# Altium schematic sheet Main.SchDoc(Main)
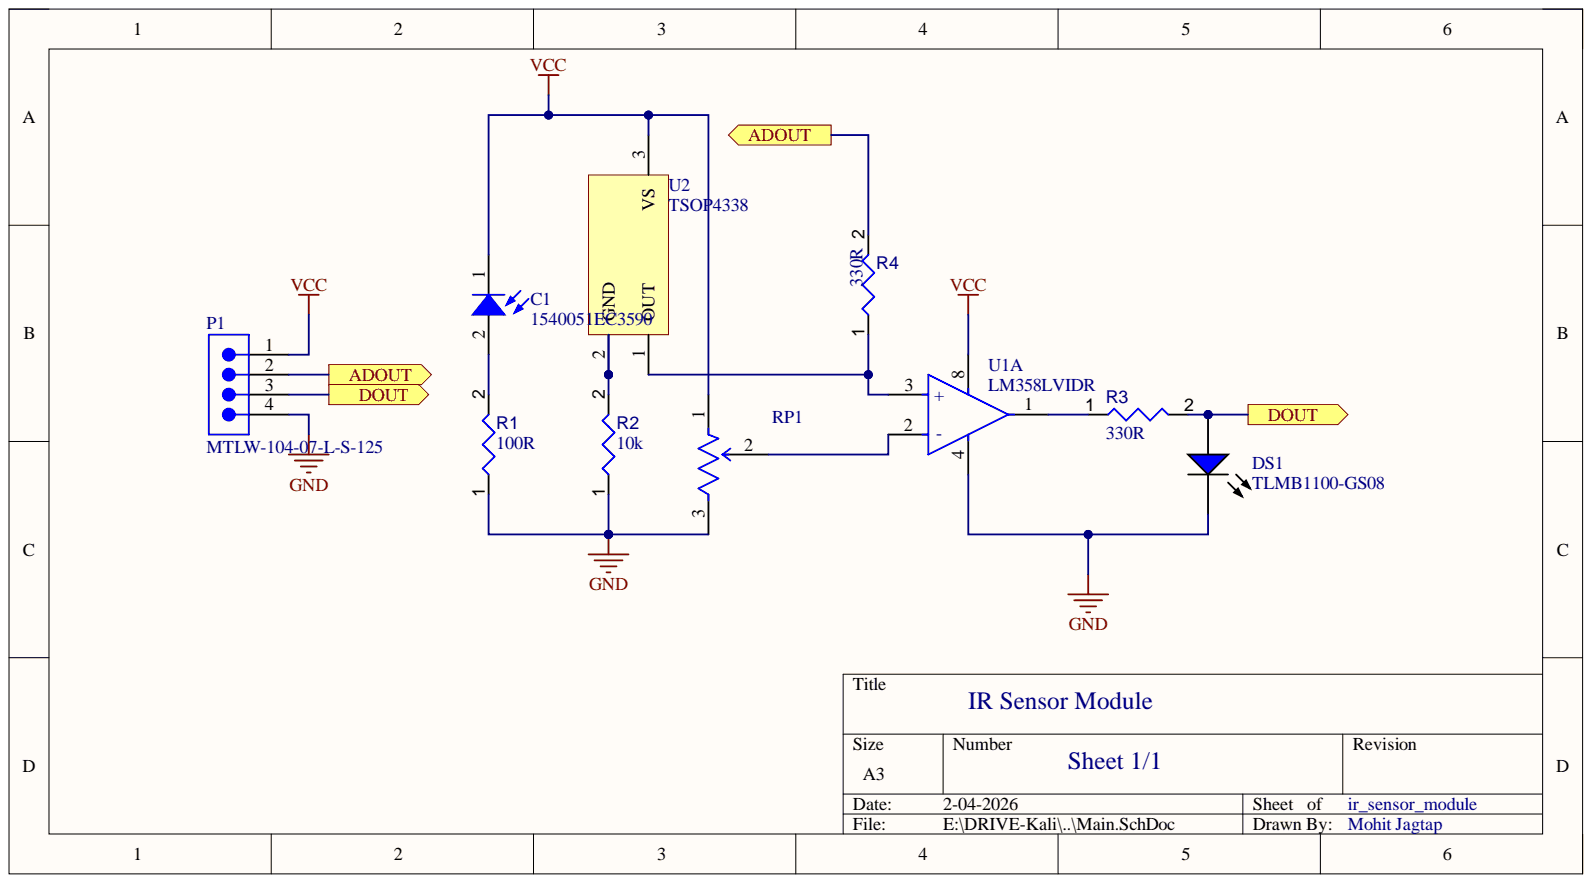
[diagram p0: Main.SchDoc]

## Parts
C1  1540051EC3590  [WL-TDRB_D5.8H8.7]
DS1  TLMB1100-GS08  [VISH-TLMX1100-2_V]
P1  MTLW-104-07-L-S-125  [SMTC-MTLW-104-07-X-S-125]
R1  value 100R  [RESA60-630X240]  (hidden comment: MFR-25FBF52-1K)
R2  value 10k  [RESA60-630X240]  (hidden comment: MFR-25FBF52-1K)
R3  value 330R  [RESA60-630X240]  (hidden comment: MFR-25FBF52-1K)
R4  value 330R  [RESA60-630X240]  (hidden comment: MFR-25FBF52-1K)
RP1  3362P-1-104TLF  [FP-3362P-T-MFG]  (value not drawn)
U1  LM358LVIDR  [FP-D0008A-MFG]  (part A)
U2  TSOP4338  [FP-6_550-5169_01-4-MFG]

## Ports
ADOUT, DOUT

## Nets
GND: DS1.2 P1.4 R1.1 R2.1 RP1.3 U1.4
VCC: C1.1 P1.1 RP1.1 U1.8 U2.3
ADOUT: P1.2 R4.2 ; port ADOUT
DOUT: DS1.1 P1.3 R3.2 ; port DOUT
NetC1_2: C1.2 R1.2
NetR2_2: R2.2 U2.2
NetR3_1: R3.1 U1.1
NetR4_1: R4.1 U1.3(+) U2.1
NetRP1_2: RP1.2 U1.2(-)

## Library data of the parts
C1 = CMP-03913-98667841-1: 1540051EC3590 WL-TDRB THT Photodiode Round Black; Max Reverse Voltage (DC): 30V; Viewing Angle: 35°; Component Kind: Standard; Height: 8.7mm; Length: 5.8mm; Library Name: Altium Content Vault; Max Operating Temperature: 85°C; Min Operating Temperature: -40°C; NexarPartId: 98667841; RoHS: Compliant; Width: 5mm
DS1 = CMP-007-00028-2: Blue LED, 10 mA, 3.9 V, -40 to 100 degC, 2-Pin SMD (0603), RoHS, Tape and Reel; Manufacturer: Vishay Semiconductor; Forward Current: 10mA; Forward Voltage: 3.9V; Lens Style: Diffused; Luminous Intensity: 5mcd; Power: 68mW; Viewing Angle: 160degrees; Case/Package: 0603; Colour: Blue; Component Kind: Standard; Datasheet URL: http://download.siliconexpert.com/pdfs/2016/8/7/12/43/26/667/vsh_/manual/379263299541529tlmb1100.pdf; Datasheet Version: Rev. 2.7, 03/2016; Illumination Colour: Blue; Manufacturer URL: http://www.vishay.com/; Max Operating Temperature: 100°C; Min Operating Temperature: -40°C; Mounting Technology: SM; Nominal Vf: 3.9V; Package Description: 2-Pin Surface Mount Device, Body 1.6 x 0.8 mm; Package Quantity: 3000; Package Reference: 0603; Packaging: TapeandReel; Pins: 2; RoHS Compliant: True; Library Name: Mohit Jagtap
P1 = CMP-1024-00072-1: Low Profile .025" SQ Post Header, Through-hole, Vertical, -55 to 125 degC, 2.54 mm Pitch, 4-Pin, Male, RoHS; Manufacturer: Samtec; Pitch: 2.54 mm; Component Kind: Standard; ComponentLink1Description: Manufacturer URL; ComponentLink1URL: http://www.samtec.com; ComponentLink2Description: Datasheet; ComponentLink2URL: http://www.samtec.com/documents/webfiles/pdf/MTLW_TH.PDF; ComponentLink3Description: Package Specification; ComponentLink3URL: http://www.samtec.com/documents/webfiles/cpdf/MTLW-1XX-XX-XX-X-XXX-XX-XX-MKT1.pdf; DatasheetVersion: F-214; Library Name: Altium Content Vault; Mounting Technology: Through-hole; Orientation: Vertical; PackageDescription: Low Profile Terminal Assembly; PackageReference: MTLW-104-07-X-S-125; PackageVersion: T, 6/1991; PartNumber: MTLW-104-07-L-S-125; RoHS: True; Temperature Range: -55 to 125 degC
R1-R4 = CMP-009-00048-4: Axial Resistor, 1 KOhm, +/- 1%, 250 mW, -55 to 155 degC, 2-Pin THD, RoHS, Bulk; Manufacturer: Yageo; Power: 250mW; Voltage Rating: 250V; Case/Package: Axial; Component Kind: Standard; Composition: CarbonFilm; Datasheet URL: http://www.yageo.com/documents/recent/Yageo%20LR_MFR_2013.pdf; Datasheet Version: Apr-13; Manufacturer URL: http://www.yageo.com/; Max Operating Temperature: 155°C; Min Operating Temperature: -55°C; Mounting Technology: TH; Package Description: 2-Pin Axial Lead, Dia 2.4 mm, Body Length 6.3 mm; Package Quantity: 200; Package Reference: MFR-25; Packaging: Bulk; RoHS Compliant: True; Tolerance: 1%; Library Name: Mohit Jagtap
RP1 = CMP-07234-000031-1: TRIMMER 100K OHM 0.5W PC PIN TOP; Max Power Dissipation: 500mW; Resistance: 100kR; Temperature Coefficient: 100ppm/°C; Tolerance: 10%; Component Kind: Standard; Height: 0.185inch; Length: 0.275inch; Library Name: Altium Content Vault; Max Operating Temperature: 125°C; Min Operating Temperature: -55°C; Mount: Through Hole; Package Quantity: 50; Packaging: Rail/Tube; Power Rating: 500mW; Resistor Type: Cermet; RoHS Compliant: Yes; Series: Trimpot® 3362 - Sealed; Technology: CERMET; Termination: PC Pin; Width: 0.26inch
U1 = CMP-04895-000442-1: IC OPAMP GP 2 CIRCUIT 8SOIC; Gain Bandwidth Product: 1MHz; Input Bias Current: 15pA; Input Offset Voltage (Vos): 1mV; Number of Circuits: 2; Operating Supply Current: 90uA; Output Current per Channel: 40mA; Slew Rate: 1.5V/µs; Case/Package: SOIC; Component Kind: Standard; Library Name: Altium Content Vault; Max Operating Temperature: 125°C; Min Operating Temperature: -40°C; Mount: Surface Mount; Number of Pins: 8; Packaging: Cut Tape; RoHS Compliant: Yes
U2 = CMP-00030-24909036-1: TSOP4338 VISHAY TSOP4338 Infrared Receiver, 38 kHz, 45m, 45 , 5.5 V, 2.5 V, -25 C; Max Junction Temperature (Tj): 100°C; Max Supply Voltage: 5.5V; Min Supply Voltage: 2V; Nominal Supply Current: 700µA; Output Current: 5mA; Ambient Temperature Range High: 85°C; Component Kind: Standard; Height: 8.45mm; Length: 6mm; Library Name: Altium Content Vault; Max Operating Temperature: 85°C; Min Operating Temperature: -25°C; Mount: Through Hole; Number of Pins: 3; Operating Supply Current: 700µA; Orientation: Side View; Radiation Hardening: No; REACH SVHC: Unknown; RoHS: Compliant; Sensing Distance: 45m; Width: 5.6mm
